# Altium schematic sheet MZSD2CMT2.SchDoc
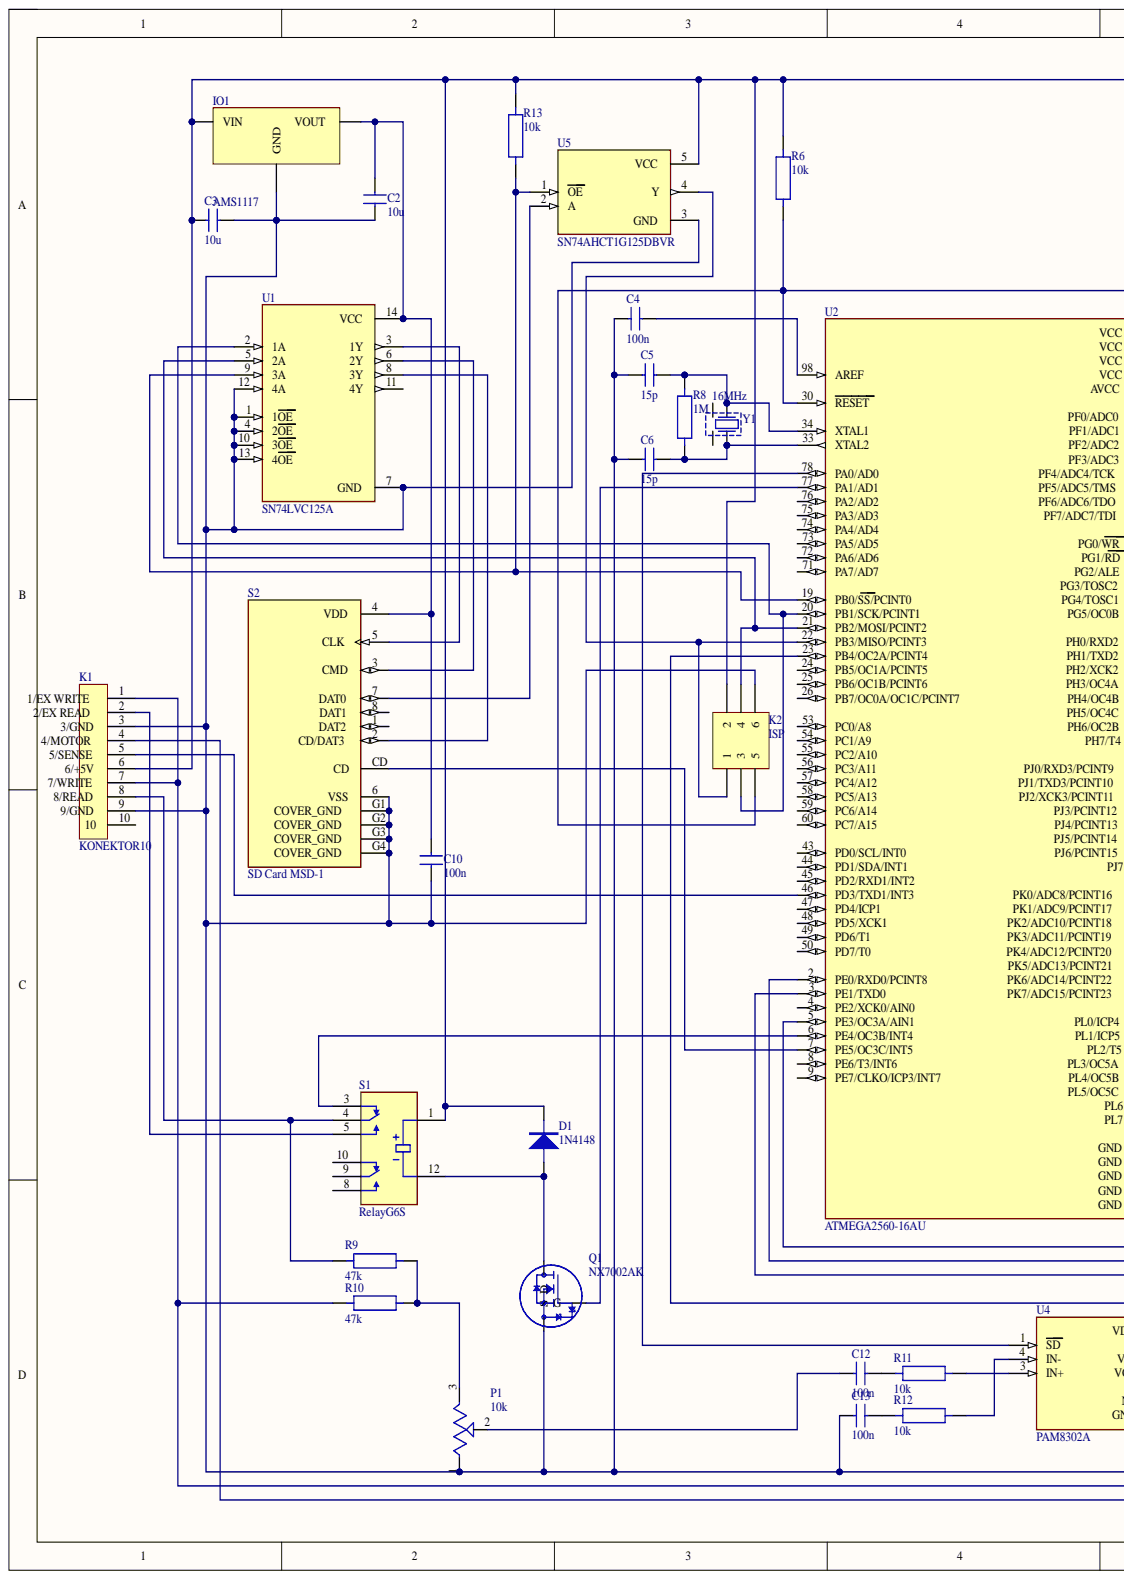
[diagram p0: MZSD2CMT2.SchDoc, left]
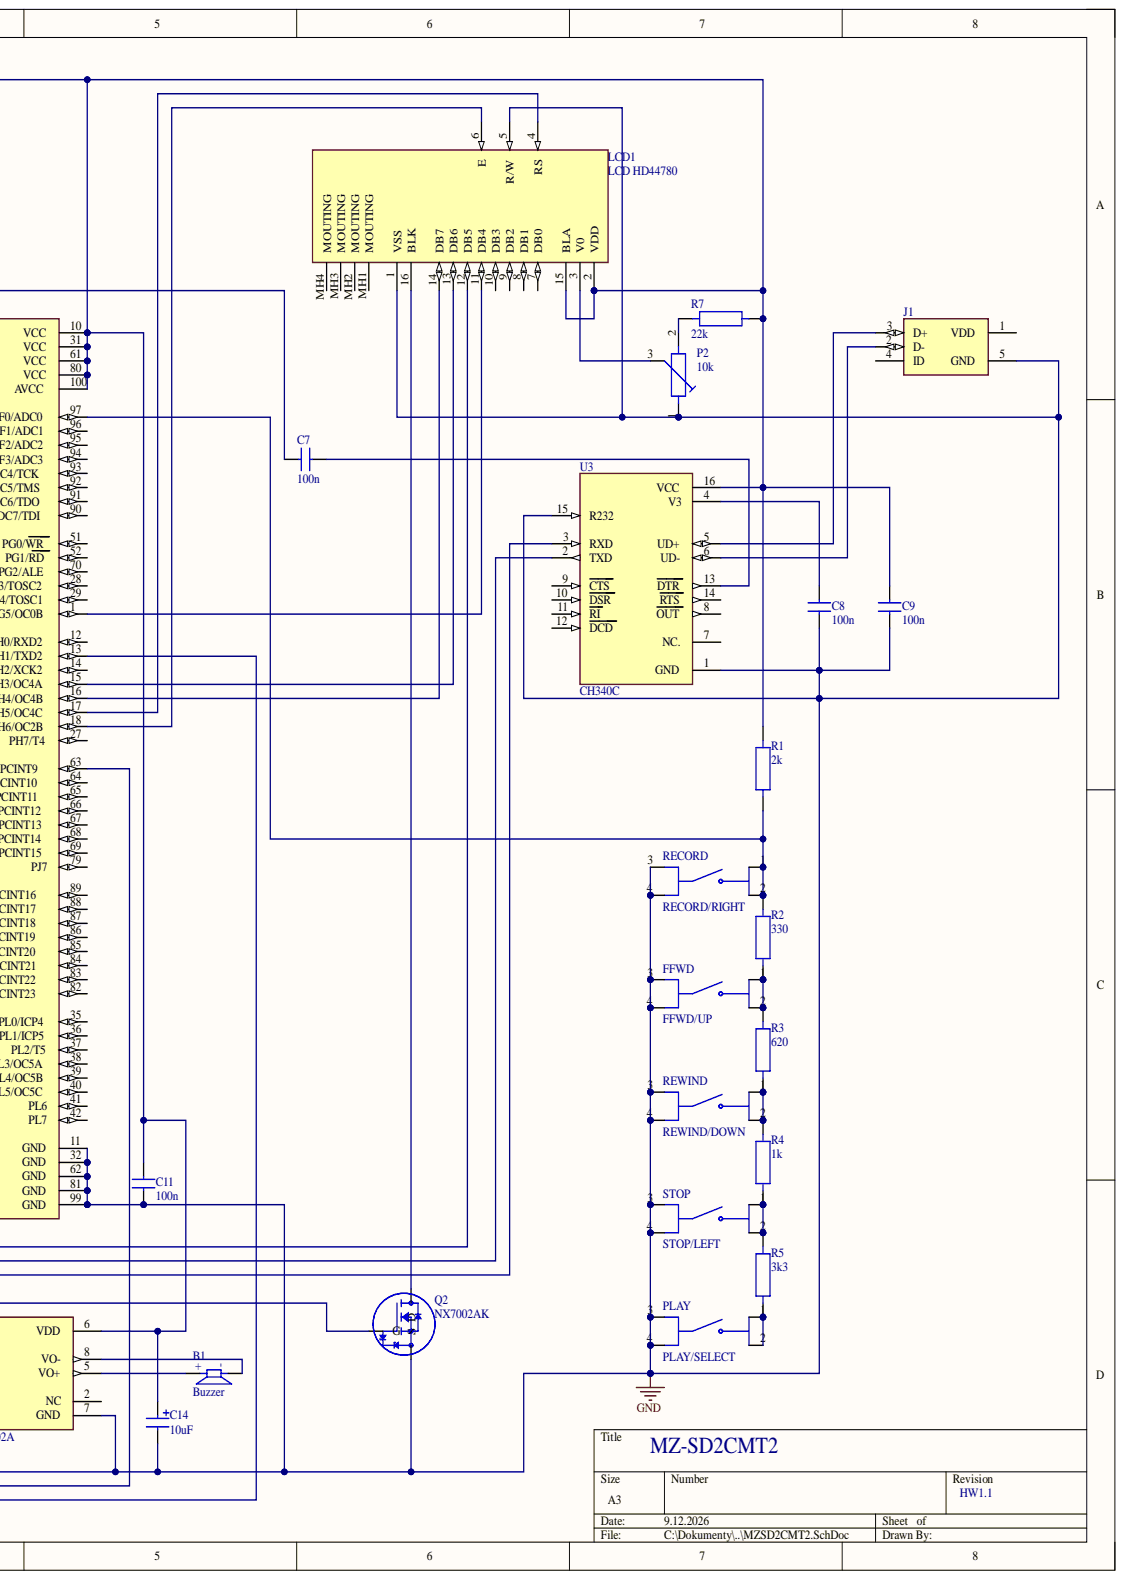
[diagram p1: MZSD2CMT2.SchDoc, right]

## Parts
B1  Buzzer  [BUZZER_6_6]
C2  10u  [SMD0805]
C3  10u  [SMD0805]
C4  100n  [SMD0805]
C5  15p  [SMD0805]
C6  15p  [SMD0805]
C7  100n  [SMD0805]
C8  100n  [SMD0805]
C9  100n  [SMD0805]
C10  100n  [SMD0805]
C11  100n  [SMD0805]
C12  100n  [SMD0805]
C13  100n  [SMD0805]
C14  10uF  [SMD1812]
D1  1N4148  [MINIMELF]
FFWD  FFWD/UP  [Switch-6]
IO1  AMS1117  [SOT229]
J1  USBMicroB  [USBMicro SMT V3]  (value not drawn)
K1  KONEKTOR10  [JST-10-XH-A-2.54]
K2  ISP  [HDR2X3_SOCKET]
LCD1  LCD HD44780  [LCD1602_2x16-STANDOFF11]
P1  10k  [TRIMR_Thumbwheel]
P2  10k  [RM065]
PLAY  PLAY/SELECT  [Switch-6]
Q1  NX7002AK  [SOT23-3]
Q2  NX7002AK  [SOT23-3]
R1  2k  [SMD0805]
R2  330  [SMD0805]
R3  620  [SMD0805]
R4  1k  [SMD0805]
R5  3k3  [SMD0805]
R6  10k  [SMD0805]
R7  22k  [SMD0805]
R8  1M  [SMD0805]
R9  47k  [SMD0805]
R10  47k  [SMD0805]
R11  10k  [SMD0805]
R12  10k  [SMD0805]
R13  10k  [SMD0805]
RECORD  RECORD/RIGHT  [Switch-6]
REWIND  REWIND/DOWN  [Switch-6]
S1  RelayG6S  [Relay-G6S-2Y]
S2  SD Card MSD-1  [SD Card MSD-1]
STOP  STOP/LEFT  [Switch-6]
U1  SN74LVC125A  [SSOP-14]
U2  ATMEGA2560-16AU  [QFP50P160X160X120-100N]
U3  CH340C  [SOIC-16Narrow]
U4  PAM8302A  [MSOP-8]
U5  SN74AHCT1G125DBVR  [SOT23-5]
Y1  16MHz  [SMD3225-4]

## Nets
GND: C2.2 C3.2 C4.1 C5.1 C6.1 C8.1 C9.1 C10.1 C11.2 C13.1 C14.2 FFWD.3 FFWD.4 IO1.1 J1.5(GND) K1.3 K1.9 K2.6 LCD1.1(VSS) LCD1.5(R/W) P1.1 P2.1 PLAY.3 PLAY.4 Q1.2 Q2.2 RECORD.3 RECORD.4 REWIND.3 REWIND.4 S2.6(VSS) S2.G1(COVER_GND) S2.G2(COVER_GND) S2.G3(COVER_GND) S2.G4(COVER_GND) STOP.3 STOP.4 U1.1(1OE) U1.4(2OE) U1.7(GND) U1.10(3OE) U1.12(4A) U1.13(4OE) U2.11(GND) U2.32(GND) U2.62(GND) U2.81(GND) U2.99(GND) U3.1(GND) U3.15(R232) U4.7(GND) U5.3(GND)
NetB1_N: B1.N U4.8(VO-)
NetB1_P: B1.P U4.5(VO+)
NetC2_1: C2.1 C10.2 IO1.2 S2.4(VDD) U1.14(VCC)
NetC3_1: C3.1 C9.2 C11.1 C14.1 D1.2 IO1.3 K1.6 K2.2 LCD1.2(VDD) LCD1.15(BLA) R1.1 R6.1 R7.2 R13.1 S1.1 U2.10(VCC) U2.31(VCC) U2.61(VCC) U2.80(VCC) U2.100(AVCC) U3.16(VCC) U4.6(VDD) U5.5(VCC)
NetC4_2: C4.2 U2.98(AREF)
NetC5_2: C5.2 R8.1 U2.34(XTAL1) Y1.3
NetC6_2: C6.2 R8.2 U2.33(XTAL2) Y1.1
NetC7_1: C7.1 K2.5 R6.2 U2.30(RESET)
NetC7_2: C7.2 U3.13(DTR)
NetC8_2: C8.2 U3.4(V3)
NetC12_1: C12.1 P1.2
NetC12_2: C12.2 R11.1
NetC13_2: C13.2 R12.1
NetD1_1: D1.1 Q1.3 S1.12
NetFFWD_1: FFWD.1 FFWD.2 R2.2 R3.1
NetJ1_2: J1.2(D-) U3.6(UD-)
NetJ1_3: J1.3(D+) U3.5(UD+)
NetK1_1: K1.1 K1.7 R10.1 U2.63(PJ0/RXD3/PCINT9)
NetK1_2: K1.2 S1.5
NetK1_4: K1.4 U2.13(PH1/TXD2)
NetK1_5: K1.5 U2.46(PD3/TXD1/INT3)
NetK1_8: K1.8 R9.1 S1.4
NetK2_1: K2.1 U2.22(PB3/MISO/PCINT3) U5.4(Y)
NetK2_3: K2.3 U1.2(1A) U2.20(PB1/SCK/PCINT1)
NetK2_4: K2.4 U1.5(2A) U2.21(PB2/MOSI/PCINT2)
NetLCD1_3: LCD1.3(V0) P2.3
NetLCD1_4: LCD1.4(RS) U2.17(PH5/OC4C)
NetLCD1_6: LCD1.6(E) U2.18(PH6/OC2B)
NetLCD1_11: LCD1.11(DB4) U2.1(PG5/OC0B)
NetLCD1_12: LCD1.12(DB5) U2.5(PE3/OC3A/AIN1)
NetLCD1_13: LCD1.13(DB6) U2.15(PH3/OC4A)
NetLCD1_14: LCD1.14(DB7) U2.16(PH4/OC4B)
NetLCD1_16: LCD1.16(BLK) Q2.3
NetP1_3: P1.3 R9.2 R10.2
NetP2_2: P2.2 R7.1
NetPLAY_1: PLAY.1 PLAY.2 R5.2
NetQ1_1: Q1.1 U2.77(PA1/AD1)
NetQ2_1: Q2.1 U2.23(PB4/OC2A/PCINT4)
NetR1_2: R1.2 R2.1 RECORD.1 RECORD.2 U2.97(PF0/ADC0)
NetR3_2: R3.2 R4.1 REWIND.1 REWIND.2
NetR4_2: R4.2 R5.1 STOP.1 STOP.2
NetR11_2: R11.2 U4.3(IN+)
NetR12_2: R12.2 U4.4(IN-)
NetR13_2: R13.2 U1.9(3A) U2.19(PB0/SS/PCINT0) U5.1(OE)
NetS1_3: S1.3 U2.6(PE4/OC3B/INT4)
NetS2_2: S2.2(CD/DAT3) U1.8(3Y)
NetS2_3: S2.3(CMD) U1.6(2Y)
NetS2_5: S2.5(CLK) U1.3(1Y)
NetS2_7: S2.7(DAT0) U5.2(A)
NetS2_CD: S2.CD U2.7(PE5/OC3C/INT5)
NetU2_2: U2.2(PE0/RXD0/PCINT8) U3.2(TXD)
NetU2_3: U2.3(PE1/TXD0) U3.3(RXD)
NetU2_78: U2.78(PA0/AD0) U4.1(SD)

Not connected: J1 1(VDD) 4(ID); K1 10; LCD1 7(DB0) 8(DB1) 9(DB2) 10(DB3) MH1(MOUTING) MH2(MOUTING) MH3(MOUTING) MH4(MOUTING); S1 8 9 10; S2 1(DAT2) 8(DAT1); U1 11(4Y); U2 4(PE2/XCK0/AIN0) 8(PE6/T3/INT6) 9(PE7/CLKO/ICP3/INT7) 12(PH0/RXD2) 14(PH2/XCK2) 24(PB5/OC1A/PCINT5) 25(PB6/OC1B/PCINT6) 26(PB7/OC0A/OC1C/PCINT7) 27(PH7/T4) 28(PG3/TOSC2) 29(PG4/TOSC1) 35(PL0/ICP4) 36(PL1/ICP5) 37(PL2/T5) 38(PL3/OC5A) 39(PL4/OC5B) 40(PL5/OC5C) 41(PL6) 42(PL7) 43(PD0/SCL/INT0) 44(PD1/SDA/INT1) 45(PD2/RXD1/INT2) 47(PD4/ICP1) 48(PD5/XCK1) 49(PD6/T1) 50(PD7/T0) 51(PG0/WR) 52(PG1/RD) 53(PC0/A8) 54(PC1/A9) 55(PC2/A10) 56(PC3/A11) 57(PC4/A12) 58(PC5/A13) 59(PC6/A14) 60(PC7/A15) 64(PJ1/TXD3/PCINT10) 65(PJ2/XCK3/PCINT11) 66(PJ3/PCINT12) 67(PJ4/PCINT13) 68(PJ5/PCINT14) 69(PJ6/PCINT15) 70(PG2/ALE) 71(PA7/AD7) 72(PA6/AD6) 73(PA5/AD5) 74(PA4/AD4) 75(PA3/AD3) 76(PA2/AD2) 79(PJ7) 82(PK7/ADC15/PCINT23) 83(PK6/ADC14/PCINT22) 84(PK5/ADC13/PCINT21) 85(PK4/ADC12/PCINT20) 86(PK3/ADC11/PCINT19) 87(PK2/ADC10/PCINT18) 88(PK1/ADC9/PCINT17) 89(PK0/ADC8/PCINT16) 90(PF7/ADC7/TDI) 91(PF6/ADC6/TDO) 92(PF5/ADC5/TMS) 93(PF4/ADC4/TCK) 94(PF3/ADC3) 95(PF2/ADC2) 96(PF1/ADC1); U3 7(NC.) 8(OUT) 9(CTS) 10(DSR) 11(RI) 12(DCD) 14(RTS); U4 2(NC); Y1 2 4

## Library data of the parts
C2-C13 = KOND: Capacitor; Component Kind: Standard; Library Name: Misc.schlib; Simulation: CAP
C14 = KONDEL: Capacitor; Component Kind: Standard; Library Name: Misc.schlib; Simulation: CAP
D1 = DIODE: Dioda; Component Kind: Standard; Library Name: Misc.schlib; Simulation: DIODE
FFWD PLAY RECORD REWIND STOP = Switch tact: Component Kind: Standard; Library Name: Misc.schlib
IO1 = AMS1117: 3-Terminal Positive Voltage Regulator 3.3V; Component Kind: Standard; Library Name: Misc.schlib
J1 = USBMicroB: USB 2.0 MicroB; ContactMaterial: Copper Alloy; ContactPlating: Gold (30); DrillSize: Corresponds to nominal value.; PanelGround: Without; PCB_Layout: Complies with manufacturer's recommendation.; Component Kind: Standard; ComponentLink1Description: Manufacturer Link; ComponentLink1URL: http://www.tycoelectronics.com/; ComponentLink2Description: Packaging Specification; ComponentLink2URL: http://ecommas.tycoelectronics.com/commerce/DocumentDelivery/DDEController?Action=showdoc&DocId=Customer+Drawing%7F440247%7FD%7Fpdf%7FEnglish%7FC_440247_D.pdf; DatasheetDocument: 27-Jun-2003; HousingMaterial: Thermoplastic; Library Name: Misc.schlib; PackageDocument: 27-Jun-2003; PackageReference: 440247; Published: 15-Jul-2004; Signal Integrity: USB2.0; Publisher: Altium Limited
K2 = Header 3X2: Header, 3-Pin, Dual row; Component Kind: Standard; LatestRevisionDate: 17-Jul-2002; LatestRevisionNote: Re-released for DXP Platform.; Library Name: Misc.schlib; Signal Integrity: Connector; Publisher: Altium Limited
LCD1 = LCD_HD44780: LCD 2x16,2x20, HD44780; Component Kind: Standard; Library Name: Misc.schlib
P1 = TRIMR_Thumbwheel: Component Kind: Standard; Library Name: Misc.schlib
P2 = TRIMR: Potentiometer; Component Kind: Standard; Library Name: Misc.schlib; Simulation: POT
Q1 Q2 = NX7002AK: 60 V, 190mA, single N-channel Trench MOSFET; Component Kind: Standard; Library Name: Misc.schlib
R1-R13 = REZISTOR: Resistor; Component Kind: Standard; Library Name: Misc.schlib; Simulation: RESISTOR
U1 = SN74LVC125A: Quadruple Bus Buffer Gate With 3-State Outputs; Component Kind: Standard; Library Name: LOGIO.schlib
U2 = ATMEGA2560-16AU: 8bit MCU; Component Kind: Standard; Library Name: Misc.schlib
U3 = CH340C: USB to serial chip; Component Kind: Standard; Library Name: Misc.schlib
U4 = PAM8302A: Class D Amplifier; Component Kind: Standard; Library Name: Misc.schlib
Y1 = XTAL 4-PIN: Crystal Resonator; Component Kind: Standard; Library Name: Misc.schlib; Simulation: XTAL
B1 = Buzzer: Component Kind: Standard; Library Name: Misc.schlib
K1 = KONEKTOR10: Component Kind: Standard; Library Name: Misc.schlib
S1 = RelayG6S: Component Kind: Standard; Library Name: Misc.schlib
S2 = SD Card MSD-1: Component Kind: Standard; Library Name: Misc.schlib
U5 = SN74AHCT1G125DBVR: Component Kind: Standard; Library Name: LOGIO.schlib
